# Altium schematic sheet Vapeix_mini_nrf.SchDoc
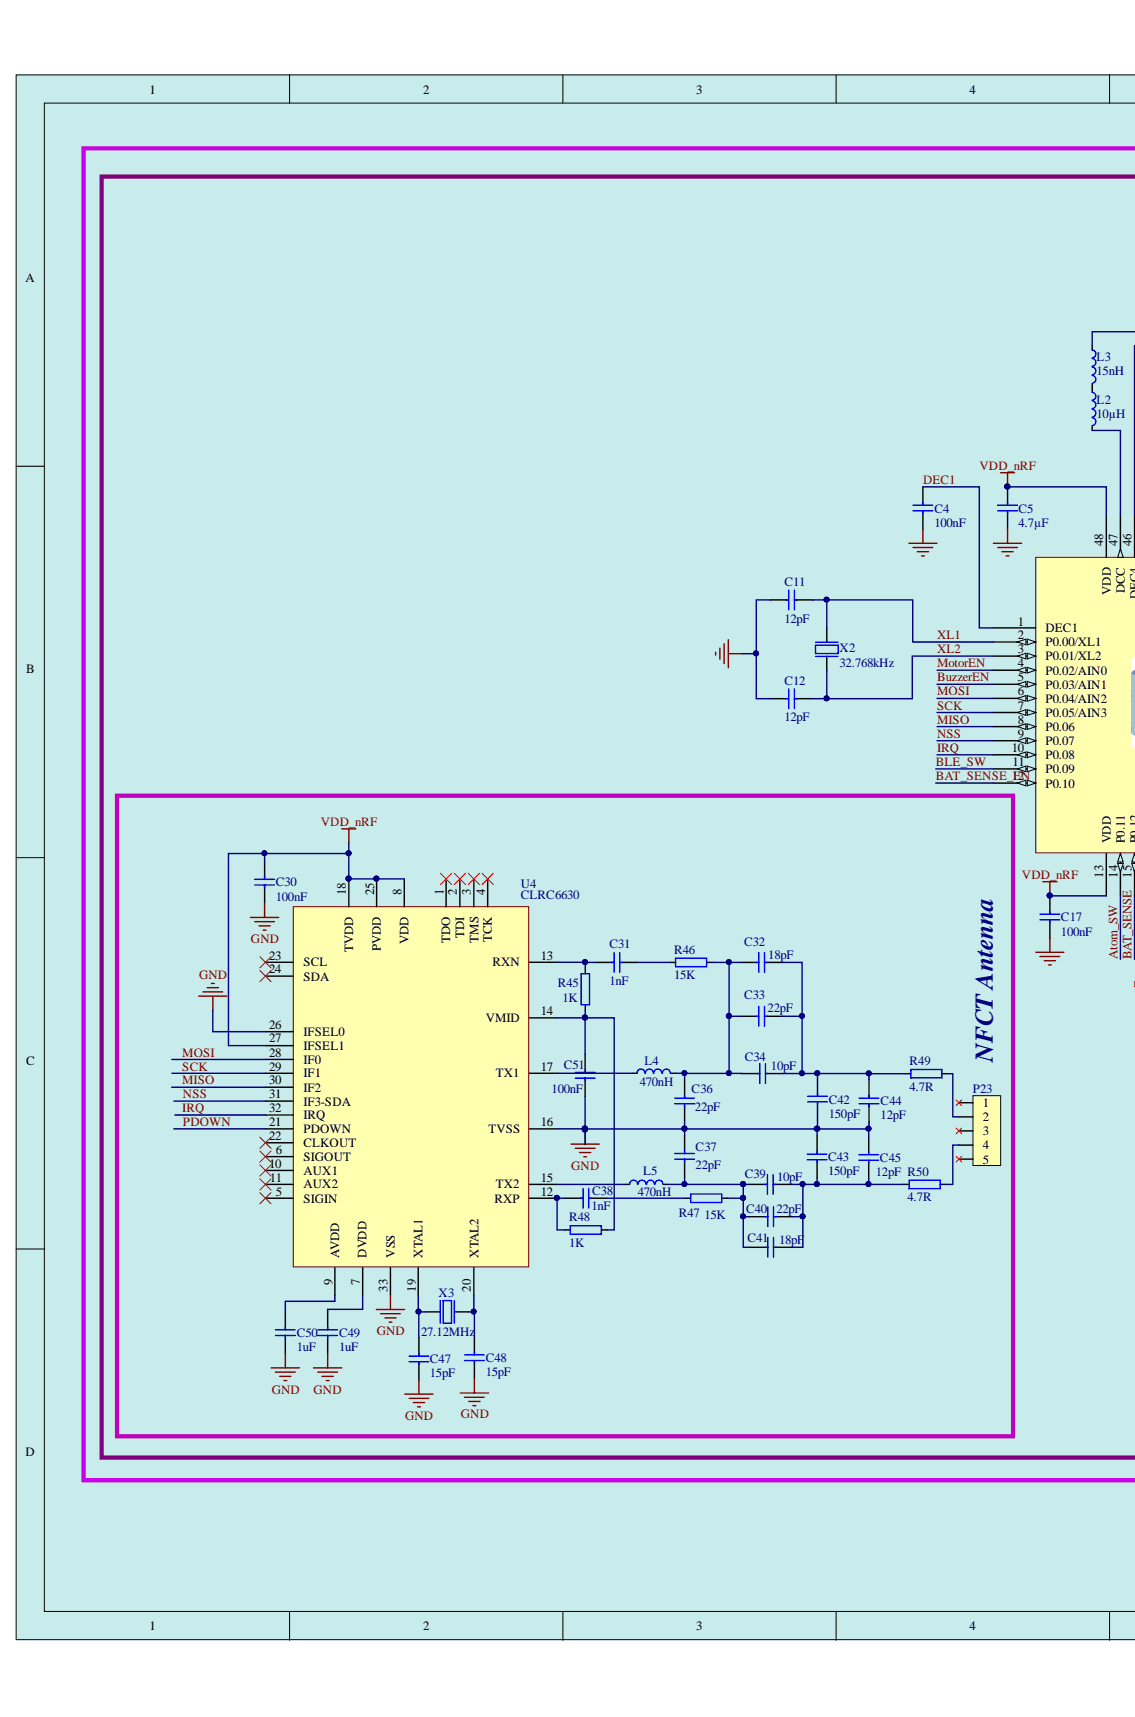
[diagram p0: Vapeix_mini_nrf.SchDoc, left]
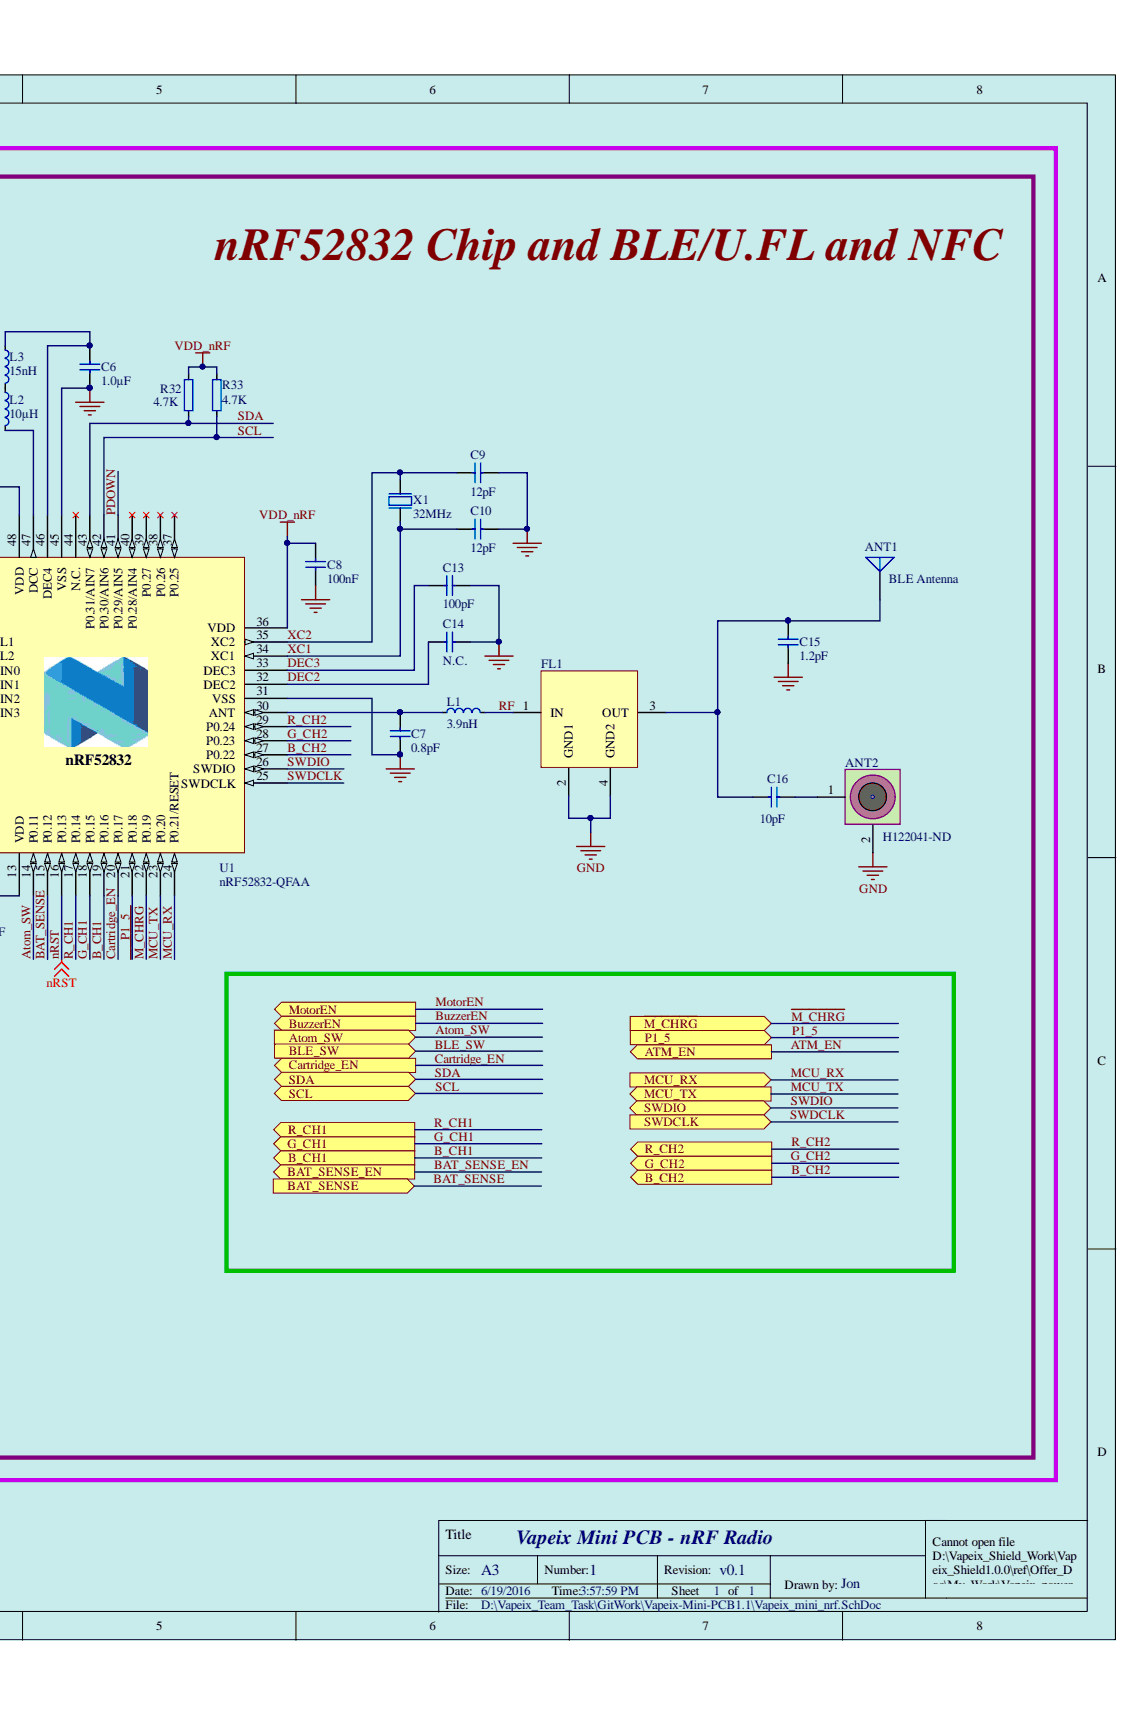
[diagram p1: Vapeix_mini_nrf.SchDoc, right]

## Parts
ANT1  BLE Antenna  [ANT_2450AT18A100]
ANT2  Supplier Part Number 1: H122041-ND  [UFL-R-SMT]  (hidden comment: U.FL-R-SMT-1(01))
C4  100nF  [C0201]
C5  4.7µF  [C0201]
C6  1.0µF  [C0201]
C7  0.8pF  [C0201]
C8  100nF  [C0201]
C9  12pF  [C0201]
C10  12pF  [C0201]
C11  12pF  [C0201]
C12  12pF  [C0201]
C13  100pF  [C0201]
C14  N.C.  [C0201]
C15  1.2pF  [C0201]
C16  10pF  [C0201]
C17  100nF  [C0201]
C30  100nF  [C0201]
C31  1nF  [C0201]
C32  18pF  [C0201]
C33  22pF  [C0201]
C34  10pF  [C0201]
C36  22pF  [C0201]
C37  22pF  [C0201]
C38  1nF  [C0201]
C39  10pF  [C0201]
C40  22pF  [C0201]
C41  18pF  [C0201]
C42  150pF  [C0201]
C43  150pF  [C0201]
C44  12pF  [C0201]
C45  12pF  [C0201]
C47  15pF  [C0201]
C48  15pF  [C0201]
C49  1uF  [C0201]
C50  1uF  [C0201]
C51  100nF  [C0201]
FL1  DEA202450BT-1294C1-H  [DEA202450BT-1294C1-H]  (value not drawn)
L1  3.9nH  [INDC0201]
L2  10µH  [INDC1608X06L]
L3  15nH  [INDC0201]
L4  470nH  [R0805]
L5  470nH  [R0805]
P23  Molex 051281-0594  [Molex 051281-0594]  (value not drawn)
R32  4.7K  [R0201]
R33  4.7K  [R0201]
R45  1K  [R0201]
R46  15K  [R0201]
R47  15K  [R0201]
R48  1K  [R0201]
R49  4.7R  [R0201]
R50  4.7R  [R0201]
U1  nRF52832-QFAA  [QFN40P600X600X90-48N]
U4  CLRC6630  [CLRC6630]
X1  32MHz  [BT-XTAL_2016]
X2  32.768kHz  [XTAL_3215]
X3  27.12MHz  [BT-XTAL_3225]

## Ports
ATM_EN, ATOM_SW, B_CH1, B_CH2, BAT_SENSE, BAT_SENSE_EN, BLE_SW, BUZZEREN, CARTRIDGE_EN, G_CH1, G_CH2, MCU_RX, MCU_TX, MOTOREN, P1_5, R_CH1, R_CH2, SCL, SDA, SWDCLK, SWDIO, ~{M_CHRG}

## Nets
GND: ANT2.2 C4.2 C5.2 C6.1 C7.1 C8.2 C9.2 C10.2 C11.1 C12.1 C13.2 C14.2 C15.2 C17.2 C30.2 C36.2 C37.1 C42.2 C43.1 C44.2 C45.1 C47.2 C48.2 C49.2 C50.2 C51.2 FL1.2(GND1) FL1.4(GND2) U1.31(VSS) U1.45(VSS) U1.49 U4.16(TVSS) U4.26(IFSEL0) U4.33(VSS) X1.2 X1.4 X3.2 X3.4
VDD_NRF: C5.1 C8.1 C17.1 C30.1 R32.2 R33.2 U1.13(VDD) U1.36(VDD) U1.48(VDD) U4.8(VDD) U4.18(TVDD) U4.25(PVDD) U4.27(IFSEL1)
ATOM_SW: U1.14(P0.11) ; port ATOM_SW
B_CH1: U1.19(P0.16) ; port B_CH1
B_CH2: U1.27(P0.22) ; port B_CH2
BAT_SENSE: U1.15(P0.12) ; port BAT_SENSE
BAT_SENSE_EN: U1.12(P0.10) ; port BAT_SENSE_EN
BLE_SW: U1.11(P0.09) ; port BLE_SW
BUZZEREN: U1.5(P0.03/AIN1) ; port BUZZEREN
CARTRIDGE_EN: U1.20(P0.17) ; port CARTRIDGE_EN
DEC1: C4.1 U1.1(DEC1)
DEC2: C14.1 U1.32(DEC2)
DEC3: C13.1 U1.33(DEC3)
G_CH1: U1.18(P0.15) ; port G_CH1
G_CH2: U1.28(P0.23) ; port G_CH2
IRQ: U1.10(P0.08) U4.32(IRQ)
~{M_CHRG}: U1.22(P0.19) ; port ~{M_CHRG}
MCU_RX: U1.24(P0.21/RESET) ; port MCU_RX
MCU_TX: U1.23(P0.20) ; port MCU_TX
MISO: U1.8(P0.06) U4.30(IF2)
MOSI: U1.6(P0.04/AIN2) U4.28(IF0)
MOTOREN: U1.4(P0.02/AIN0) ; port MOTOREN
NRST: U1.16(P0.13)
NSS: U1.9(P0.07) U4.31(IF3-SDA)
P1_5: U1.21(P0.18) ; port P1_5
PDOWN: U1.41(P0.29/AIN5) U4.21(PDOWN)
R_CH1: U1.17(P0.14) ; port R_CH1
R_CH2: U1.29(P0.24) ; port R_CH2
RF: FL1.1(IN) L1.2
SCK: U1.7(P0.05/AIN3) U4.29(IF1)
SCL: R33.1 U1.42(P0.30/AIN6) ; port SCL
SDA: R32.1 U1.43(P0.31/AIN7) ; port SDA
SWDCLK: U1.25(SWDCLK) ; port SWDCLK
SWDIO: U1.26(SWDIO) ; port SWDIO
XC1: C10.1 U1.34(XC1) X1.1
XC2: C9.1 U1.35(XC2) X1.3
XL1: C11.2 U1.2(P0.00/XL1) X2.1
XL2: C12.2 U1.3(P0.01/XL2) X2.2
NetANT1_1: ANT1.1 C15.1 C16.1 FL1.3(OUT)
NetANT2_1: ANT2.1 C16.2
NetC6_2: C6.2 L3.1 U1.46(DEC4)
NetC7_2: C7.2 L1.1 U1.30(ANT)
NetC31_1: C31.1 R46.2
NetC31_2: C31.2 R45.1 U4.13(RXN)
NetC32_1: C32.1 C33.1 C34.1 C42.1 C44.1 R49.2
NetC32_2: C32.2 C33.2 C34.2 C36.1 L4.2 R46.1
NetC37_2: C37.2 C39.2 C40.2 C41.2 L5.2 R47.1
NetC38_1: C38.1 R47.2
NetC38_2: C38.2 R48.2 U4.12(RXP)
NetC39_1: C39.1 C40.1 C41.1 C43.2 C45.2 R50.2
NetC47_1: C47.1 U4.19(XTAL1) X3.3
NetC48_1: C48.1 U4.20(XTAL2) X3.1
NetC49_1: C49.1 U4.7(DVDD)
NetC50_1: C50.1 U4.9(AVDD)
NetC51_1: C51.1 R45.2 R48.1 U4.14(VMID)
NetL2_1: L2.1 L3.2
NetL2_2: L2.2 U1.47(DCC)
NetL4_1: L4.1 U4.17(TX1)
NetL5_1: L5.1 U4.15(TX2)
NetP23_2: P23.2 R49.1
NetP23_4: P23.4 R50.1
ATM_EN: ; port ATM_EN
NRF52832 CHIP AND BLE/U.FL AND NFC:

Not connected: P23 1 3 5; U1 37(P0.25) 38(P0.26) 39(P0.27) 40(P0.28/AIN4) 44(N.C.); U4 1(TDO) 2(TDI) 3(TMS) 4(TCK) 5(SIGIN) 6(SIGOUT) 10(AUX1) 11(AUX2) 22(CLKOUT) 23(SCL) 24(SDA)

## Library data of the parts
ANT1 = Antenna: Generic Antenna; Component Kind: Standard; LatestRevisionDate: 17-Jul-2002; LatestRevisionNote: Re-released for DXP Platform.; Library Name: Miscellaneous Devices.IntLib; PackageReference: PIN1; Published: 24-Mar-1999; Publisher: Altium Limited
ANT2 = U.FL-R-SMT-1(01): CONN UMC JACK STR 50 OHM SMD; Manufacturer: Hirose Electric Co Ltd; Body Finish: Silver; Body Material: Phosphor Bronze; Center Contact Plating: Gold; Connector Style: U.FL, Ultra Miniature Coaxial; Connector Type: Jack, Male Pin; Contact Termination: Solder; Dielectric Material: Liquid Crystal Polymer (LCP); Frequency - Max: 6GHz; Impedance: 50 Ohm; Cable Group: -; Category: Connectors, Interconnects; Center Contact Material: Phosphor Bronze; Component Kind: Standard; ComponentLink1Description: http://media.digikey.com/pdf/Data%20Sheets/Hirose%20PDFs/UFL%20Series.pdf; ComponentLink1URL: http://media.digikey.com/pdf/Data%20Sheets/Hirose%20PDFs/UFL%20Series.pdf; Fastening Type: Snap-On; Features: -; Housing Color: Silver; Ingress Protection: -; Manufacturer Part Number: U.FL-R-SMT-1(01); Mounting Type: Surface Mount; Operating Temperature: -40°C ~ 90°C; Packaging: Bulk; Pricing 1: 1=1.28, 10=1.122, 25=1.056, 50=1.012, 100=0.968, 250=0.88, 500=0.792, 1000=0.704, 2500=0.638 (USD); RoHS: RoHS Compliant; Shield Termination: Solder; Stock 1: 2248; Supplier 1: Digi-Key; Voltage Rating: -; Library Name: VapeixS_LIB.SchLib
C4 C8 C17 = C0402-100n: Capacitor, X7R, ±10%; Artikkelnr Noca: 20039200; Artikkelnr Simpro: N.A.; Database Table Name: Capacitors$; Note: 16V; Component Kind: Standard; Footprint Doc: 0402; LatestRevisionDate: 2009-11-23; Library Name: Nordic Passive DB.DbLib; Part Number: C0402-100n; Pin Count: 2; Published: 2009-05-08; Value: 100nF; Publisher: Nordic Semiconductor
C5 = C0603-4µ7: Capacitor, X5R, ±10%; Artikkelnr Noca: 20069060; Artikkelnr Simpro: N.A.; Database Table Name: Capacitors$; Note: 6.3V; Component Kind: Standard; Footprint Doc: 0603; LatestRevisionDate: 2009-11-23; Library Name: Nordic Passive DB.DbLib; Part Number: C0603-4µ7; Pin Count: 2; Published: 2009-05-08; Value: 4.7µF; Publisher: Nordic Semiconductor
C6 = C0603-1µ0: Capacitor, X7R, ±10%; Artikkelnr Noca: 20039335; Artikkelnr Simpro: N.A.; Database Table Name: Capacitors$; Note: 16V; Component Kind: Standard; Footprint Doc: 0603; LatestRevisionDate: 2013-06-05; Library Name: Nordic Passive DB.DbLib; Part Number: C0603-1µ0; Pin Count: 2; Published: 2009-05-08; Value: 1.0µF; Publisher: Nordic Semiconductor
C7 = C0402-0.8p: Capacitor, NP0, ±5%; Artikkelnr Noca: 20029147; Artikkelnr Simpro: N.A.; Database Table Name: Capacitors$; Note: 50V; Component Kind: Standard; Footprint Doc: 0402; LatestRevisionDate: 2012-11-21; Library Name: Nordic Passive DB.DbLib; Part Number: C0402-0.8p; Pin Count: 2; Published: 2009-05-08; Value: 0.8pF; Publisher: Nordic Semiconductor
C9-C12 = C0402-12p: Capacitor, NP0, ±2%; Artikkelnr Noca: 20029159; Artikkelnr Simpro: N.A.; Database Table Name: Capacitors$; Note: 50V; Component Kind: Standard; Footprint Doc: 0402; LatestRevisionDate: 2011-05-11; Library Name: Nordic Passive DB.DbLib; Part Number: C0402-12p; Pin Count: 2; Published: 2009-05-08; Value: 12pF; Publisher: Nordic Semiconductor
C13 = C0402-100p: Capacitor, NP0, ±5%; Artikkelnr Noca: 20029135; Artikkelnr Simpro: N.A.; Database Table Name: Capacitors$; Note: 50V; Component Kind: Standard; Footprint Doc: 0402; LatestRevisionDate: 2009-05-08; Library Name: Nordic Passive DB.DbLib; Part Number: C0402-100p; Pin Count: 2; Published: 2009-05-08; Value: 100pF; Publisher: Nordic Semiconductor
C14 C16 = C0402-N.C.: Not mounted; Artikkelnr Noca: N.A.; Database Table Name: Capacitors$; Note: Chip Cap; Artikkelnr Simpro: N.A.; Component Kind: Standard; Footprint Doc: 0402; LatestRevisionDate: 2009-05-08; Library Name: Nordic Passive DB.DbLib; Part Number: C0402-N.C.; Pin Count: 2; Published: 2009-05-08; Publisher: Nordic Semiconductor
  C14: Value: N.C.
  C16: Value: 10pF
C15 = C0402-1.2p: Capacitor, NP0, ±0.1pF; Artikkelnr Noca: 20029157; Artikkelnr Simpro: N.A.; Database Table Name: Capacitors$; Note: 50V; Component Kind: Standard; Footprint Doc: 0402; LatestRevisionDate: 2011-05-11; Library Name: Nordic Passive DB.DbLib; Part Number: C0402-1.2p; Pin Count: 2; Published: 2009-05-08; Value: 1.2pF; Publisher: Nordic Semiconductor
C30-C34 C36-C45 C47-C51 = C0402-300p: Capacitor, NP0, ±5%; Artikkelnr Noca: N.A.; Database Table Name: Capacitors$; Note: 50V; Artikkelnr Simpro: N.A.; Component Kind: Standard; Footprint Doc: 0402; LatestRevisionDate: 2015-05-29; Library Name: Nordic Passive DB.DbLib; Part Number: C0402-300p; Pin Count: 2; Published: 2015-05-29; Supplier: Digi-Key; Supplier part number: 490-3234-1-ND; Publisher: Nordic Semiconductor
  C30 C51: Value: 100nF
  C31 C38: Value: 1nF
  C32 C41: Value: 18pF
  C33 C36 C37 C40: Value: 22pF
  C34 C39: Value: 10pF
  C42 C43: Value: 150pF
  C44 C45: Value: 12pF
  C47 C48: Value: 15pF
  C49 C50: Value: 1uF
FL1 = DEA202450BT-1294C1-H (2): FILTER BANDPASS WLAN&BLUETOOTH; Manufacturer: TDK Corporation; Category: Filters; Component Kind: Standard; ComponentLink1Description: https://product.tdk.com/info/en/documents/data_sheet/rf_bpf_dea202450bt-1294c1-h_en.pdf; ComponentLink1URL: https://product.tdk.com/info/en/documents/data_sheet/rf_bpf_dea202450bt-1294c1-h_en.pdf; Manufacturer Part Number: DEA202450BT-1294C1-H; Pricing 1: 1=0.51, 10=0.477, 100=0.4252, 250=0.40324, 500=0.33724, 1000=0.26173 (USD); RoHS: RoHS Compliant; Stock 1: 14479; Supplier 1: Digi-Key; Supplier Part Number 1: 445-172335-1-ND; Library Name: VapeixS_LIB.SchLib
L1 L4 L5 = L0402-3n9: Inductor, 170mA, ±0.1nH; Manufacturer: Murata; Manufacturer Part Number: LQP15MN3N9B02D; Artikkelnr Noca: 33029151; Artikkelnr Simpro: N.A.; Database Table Name: Inductors$; Note: High frequency chip inductor ±5%; Component Kind: Standard; Datasheet: http://search.murata.co.jp/Ceramy/image/img/PDF/ENG/L0075S0084LQP15M.pdf; Footprint Doc: 0402; LatestRevisionDate: 2012-09-19; Library Name: Nordic Passive DB.DbLib; Part Number: L0402-3n9; Pin Count: 2; Published: 2009-05-08; Supplier: Digi-Key; Supplier part number: 490-1131-1-ND; Publisher: Nordic Semiconductor
  L1: Value: 3.9nH
  L4 L5: Value: 470nH
L2 = L0603-10µ: Inductor, 80mA, ±10%; 2nd source part number: 178-6105; Manufacturer: Taiyo Yuden; Manufacturer Part Number: LBMF1608T100K; Artikkelnr Noca: 33029314; Artikkelnr Simpro: N.A.; Database Table Name: Inductors$; Note: LBMF1608T100K 10µH 80mA 0603; 2nd source Supplier: Farnell; Component Kind: Standard; Datasheet: http://www.yuden.co.jp/ut/product/pdf/elb2_e.pdf; Footprint Doc: 0603; LatestRevisionDate: 2012-09-19; Library Name: Nordic Passive DB.DbLib; Part Number: L0603-10µ; Pin Count: 2; Published: 2010-02-24; Supplier: Digi-Key; Supplier part number: 587-1714-1-ND; Value: 10µH; Publisher: Nordic Semiconductor
L3 = L0402-15n: Inductor, 320mA, ±5%; 2nd source part number: 658-1328; Manufacturer: Taiyo Yuden; Manufacturer Part Number: HK100515NJ-T; Artikkelnr Noca: 33029323; Artikkelnr Simpro: N.A.; Database Table Name: Inductors$; Note: High frequency chip inductor ±10%; 2nd source Supplier: Farnell; Component Kind: Standard; Datasheet: http://www.yuden.co.jp/productdata/catalog/en/mlci04_e.pdf; Footprint Doc: 0402; LatestRevisionDate: 2012-09-19; Library Name: Nordic Passive DB.DbLib; Part Number: L0402-15n; Pin Count: 2; Published: 2010-07-12; Supplier: Digi-Key; Supplier part number: 587-1521-1-ND; Value: 15nH; Publisher: Nordic Semiconductor
P23 = (no symbol name): CONN FFC FPC 5POS 0.50MM R/A; Artikkelnr Noca: N.A.; Artikkelnr Simpro: N.A.; Component Kind: Standard; Datasheet: http://www.molex.com/pdm_docs/sd/512810694_sd.pdf; Footprint Doc: Molex 051281-0594; LatestRevisionDate: 2015-06-22; Library Name: pca10040.SCHLIB; Manufacturer: Molex; Manufacturer Part Number: 051281-0594; Part Number: Molex 051281-0594; Pin Count: 7; Published: 2015-06-22; Supplier: Digi-Key; Supplier part number: WM9836CT-ND; Value: Molex 051281-0594; Publisher: Nordic Semiconductor
R32 R33 = R0402-10k: Resistor, ±1%, 0.063W; Artikkelnr Noca: 27049097; Artikkelnr Simpro: N.A.; Database Table Name: Resistors$; Note: Chip Res 1%; Component Kind: Standard; Footprint Doc: 0402; LatestRevisionDate: 2009-11-23; Library Name: Nordic Passive DB.DbLib; Part Number: R0402-10k; Pin Count: 2; Published: 2009-05-08; Supplier: Farnell; Supplier part number: 173-8864; Value: 4.7K; Publisher: Nordic Semiconductor
R45-R50 = R0402-0R: Resistor, ±5%, 0.063W; Artikkelnr Noca: 27049000; Artikkelnr Simpro: N.A.; Database Table Name: Resistors$; Note: Chip Res 5%; Component Kind: Standard; Footprint Doc: 0402; LatestRevisionDate: 2009-11-23; Library Name: Nordic Passive DB.DbLib; Part Number: R0402-0R; Pin Count: 2; Published: 2009-05-08; Supplier: Farnell; Supplier part number: 146-9661; Publisher: Nordic Semiconductor
  R45 R48: Value: 1K
  R46 R47: Value: 15K
  R49 R50: Value: 4.7R
U1 = nRF52832-QFAA: Multi-protocol Bluetooth Low Energy and 2.4GHz proprietary system-on-chip; Manufacturer: Nordic Semiconductor; Artikkelnr Noca: N.A.; Database Table Name: nRF Devices$; Artikkelnr Simpro: N.A.; Component Kind: Standard; Footprint Doc: QFN-48; LatestRevisionDate: 2014-11-26; Library Name: Nordic Active DB.DbLib; Manufacturer Part Number: nRF52832-QFAA; Part Number: nRF52832-QFAA; Pin Count: 48; Published: 2014-11-26; Supplier: Nordic Semiconductor; Value: nRF52832-QFAA; Publisher: Nordic Semiconductor
U4 = CLRC66301HN,551: IC NFC CONTACTLESS TXRX 32HVQFN; Manufacturer: NXP Semiconductors; Frequency: 13.56MHz; Interface: I²C, SPI, UART; Standards: ISO 14443, ISO 15693, 18000-3, MIFARE, NFC; Voltage - Supply: 3 V ~ 5.5 V; Category: RF/IF and RFID; Component Kind: Standard; ComponentLink1Description: http://www.nxp.com/documents/data_sheet/CLRC663.pdf; ComponentLink1URL: http://www.nxp.com/documents/data_sheet/CLRC663.pdf; Manufacturer Part Number: CLRC66301HN,551; Operating Temperature: -25°C ~ 85°C; Package / Case: 32-VFQFN Exposed Pad; Packaging: Tray; Pricing 1: 1=6.61, 10=6.059, 50=5.5086, 100=4.9577, 250=4.54456, 500=4.1314, 1000=3.71826 (USD); RoHS: RoHS Compliant; Stock 1: 3053; Supplier 1: Digi-Key; Supplier Device Package: 32-HVQFN (5x5); Type: RFID Reader; Library Name: VapeixS_LIB.SchLib
X1 = XTAL_SMD2016_32MHz_8pF_Epson: XTAL SMD 2016, 32MHz, Cl=8pF, Tol: ±10ppm, Stab: ±10ppm; Manufacturer: Epson Toyocom; Manufacturer Part Number: Q22FA12800025; Artikkelnr Noca: N.A.; Database Table Name: Crystal_Osc$; Note: XTAL SMD 32.000MHz; Artikkelnr Simpro: N.A.; Component Kind: Standard; Datasheet: http://www.epsondevice.com/docs/qd/en/DownloadServlet?id=ID000449; Footprint Doc: XTAL_2016; LatestRevisionDate: 2014-11-27; Library Name: Nordic Discrete DB.DbLib; Part Number: XTAL_SMD2016_32MHz_8pF_Epson; Pin Count: 4; Published: 2012-03-09; Supplier: Farnell; Supplier part number: 171-2806; Value: 32MHz; Publisher: Nordic Semiconductor
X2 = XTAL_FC-135 32.768k: EPSON TOYOCOM - FC-135 32.768KHZ ±20PPM,9.0PF - CRYSTAL, SM, WATCH; 2nd source part number: 127-8037; Artikkelnr Noca: 22019091; Artikkelnr Simpro: N.A.; Database Table Name: Crystal_Osc$; Note: XTAL SMD 32.768kHz, 3215; 2nd source Supplier: Farnell; Component Kind: Standard; Datasheet: http://www.farnell.com/datasheets/57480.pdf; Footprint Doc: XTAL_3215; LatestRevisionDate: 2014-03-27; Library Name: Nordic Discrete DB.DbLib; Manufacturer: Epson Toyocom; Manufacturer Part Number: FC-135 32.768KHZ ±20PPM,9.0PF; Part Number: XTAL_FC-135 32.768k; Pin Count: 2; Published: 2010-10-18; Supplier: Digi-Key; Supplier part number: FC-135 32.7680KA-AC-ND; Value: 32.768kHz; Publisher: Nordic Semiconductor
X3 = XTAL_SMD2016_32MHz_8pF_Epson: XTAL SMD 2016, 27.12MHz, Cl=10pF, Tol: ±10ppm, Stab: ±10ppm; Manufacturer: Epson Toyocom; Manufacturer Part Number: Q22FA12800025; Part Number: XTAL_SMD2016_27.12MHz_8pF_Epson; Artikkelnr Noca: N.A.; Database Table Name: Crystal_Osc$; Note: XTAL SMD 32.000MHz; Artikkelnr Simpro: N.A.; Component Kind: Standard; Datasheet: http://www.epsondevice.com/docs/qd/en/DownloadServlet?id=ID000449; Footprint Doc: XTAL_2016; LatestRevisionDate: 2014-11-27; Library Name: Nordic Discrete DB.DbLib; Pin Count: 4; Published: 2012-03-09; Supplier: Farnell; Supplier part number: 171-2806; Value: 27.12MHz; Publisher: Nordic Semiconductor
